# Altium schematic sheet 5V_Converter.SchDoc
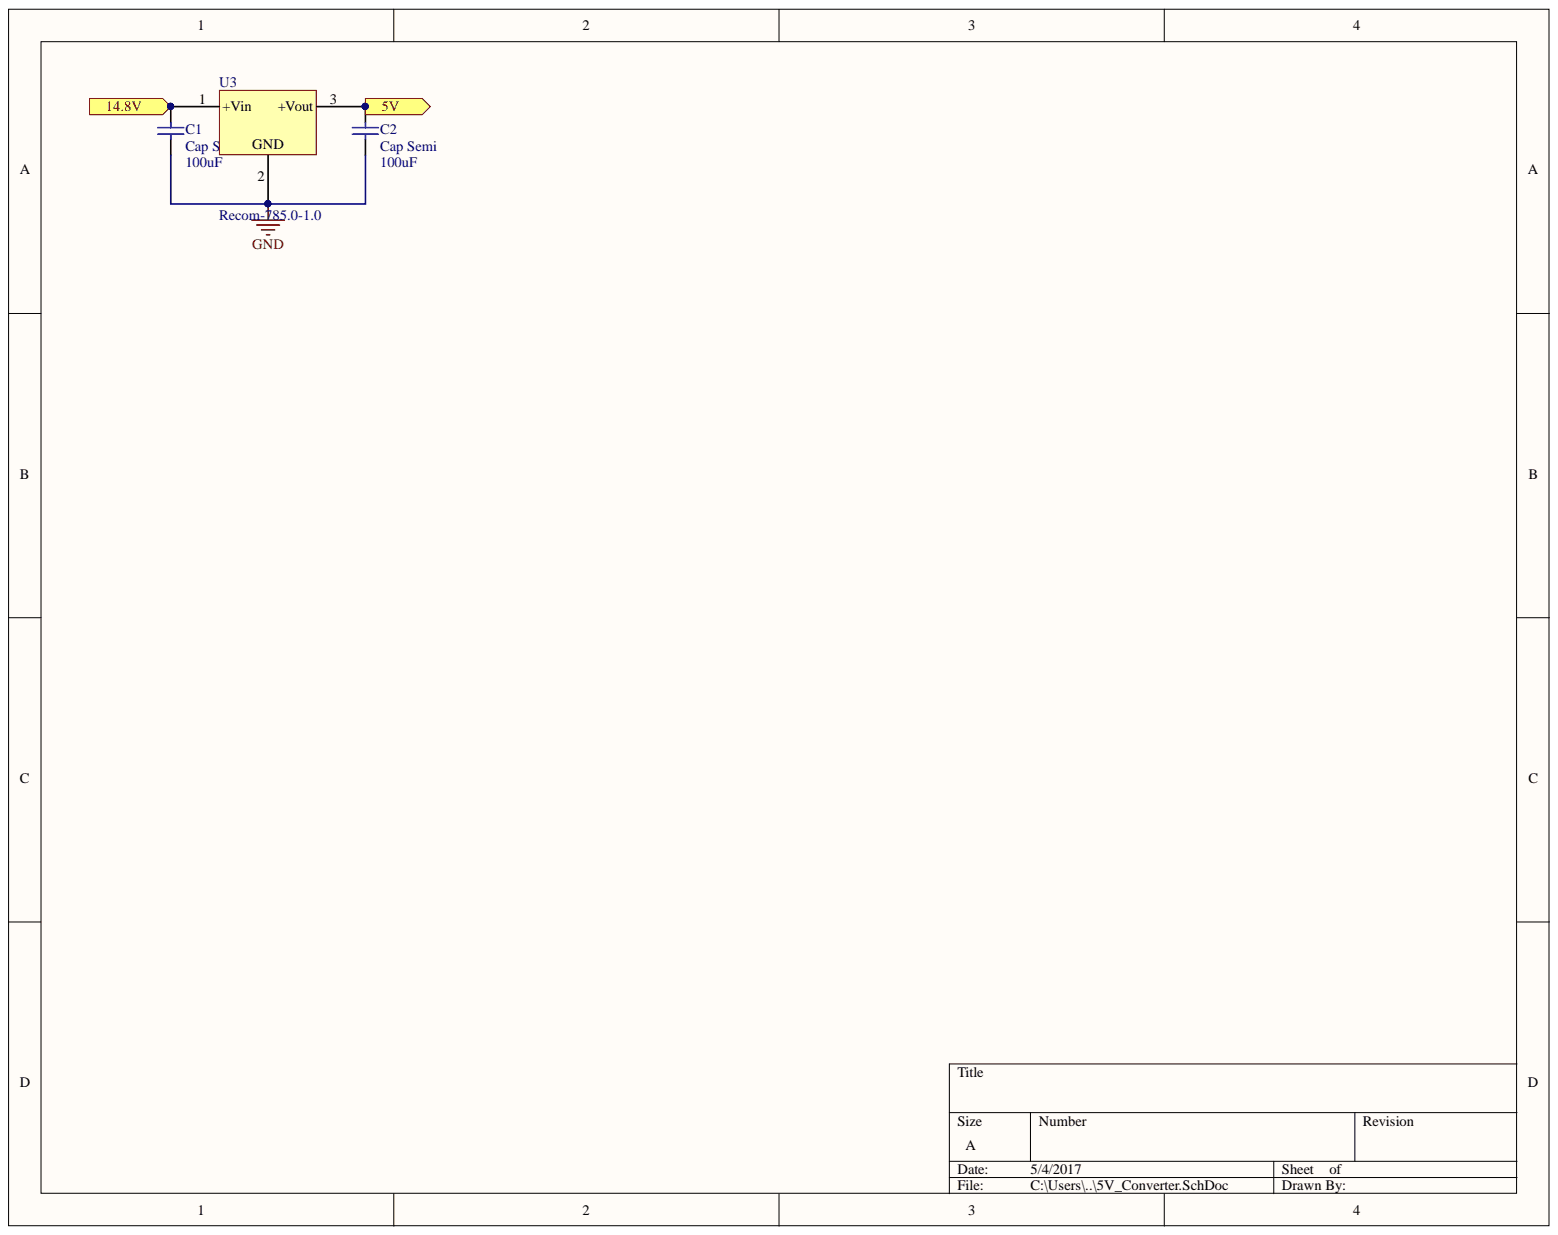
[diagram p0: 5V_Converter.SchDoc]

## Parts
C1  Cap Semi  value 100uF  [C1206]
C2  Cap Semi  value 100uF  [C1206]
U3  Recom-785.0-1.0  [Recom-78E5.0-1.0]

## Ports
5V, 14.8V

## Nets
GND: C1.1 C2.1 U3.2
5V: C2.2 U3.3 ; port 5V
14.8V: C1.2 U3.1 ; port 14.8V

## Library data of the parts
C1 C2 = Cap Semi: Capacitor (Semiconductor SIM Model); Component Kind: Standard; LatestRevisionDate: 29-May-2009; LatestRevisionNote: IPC-7351 Footprint Added.; Library Name: Miscellaneous Devices.IntLib; PackageDescription: Chip Capacitor; PackageReference: C1206; Published: 8-Jun-2000; Signal Integrity: Cap Semi; Simulation: CAP; Publisher: Altium Limited
U3 = Recom-785.0-1.0: Component Kind: Standard; Library Name: Recom-78E5.0-1.0.SchLib
